# Altium schematic sheet ADC.SchDoc
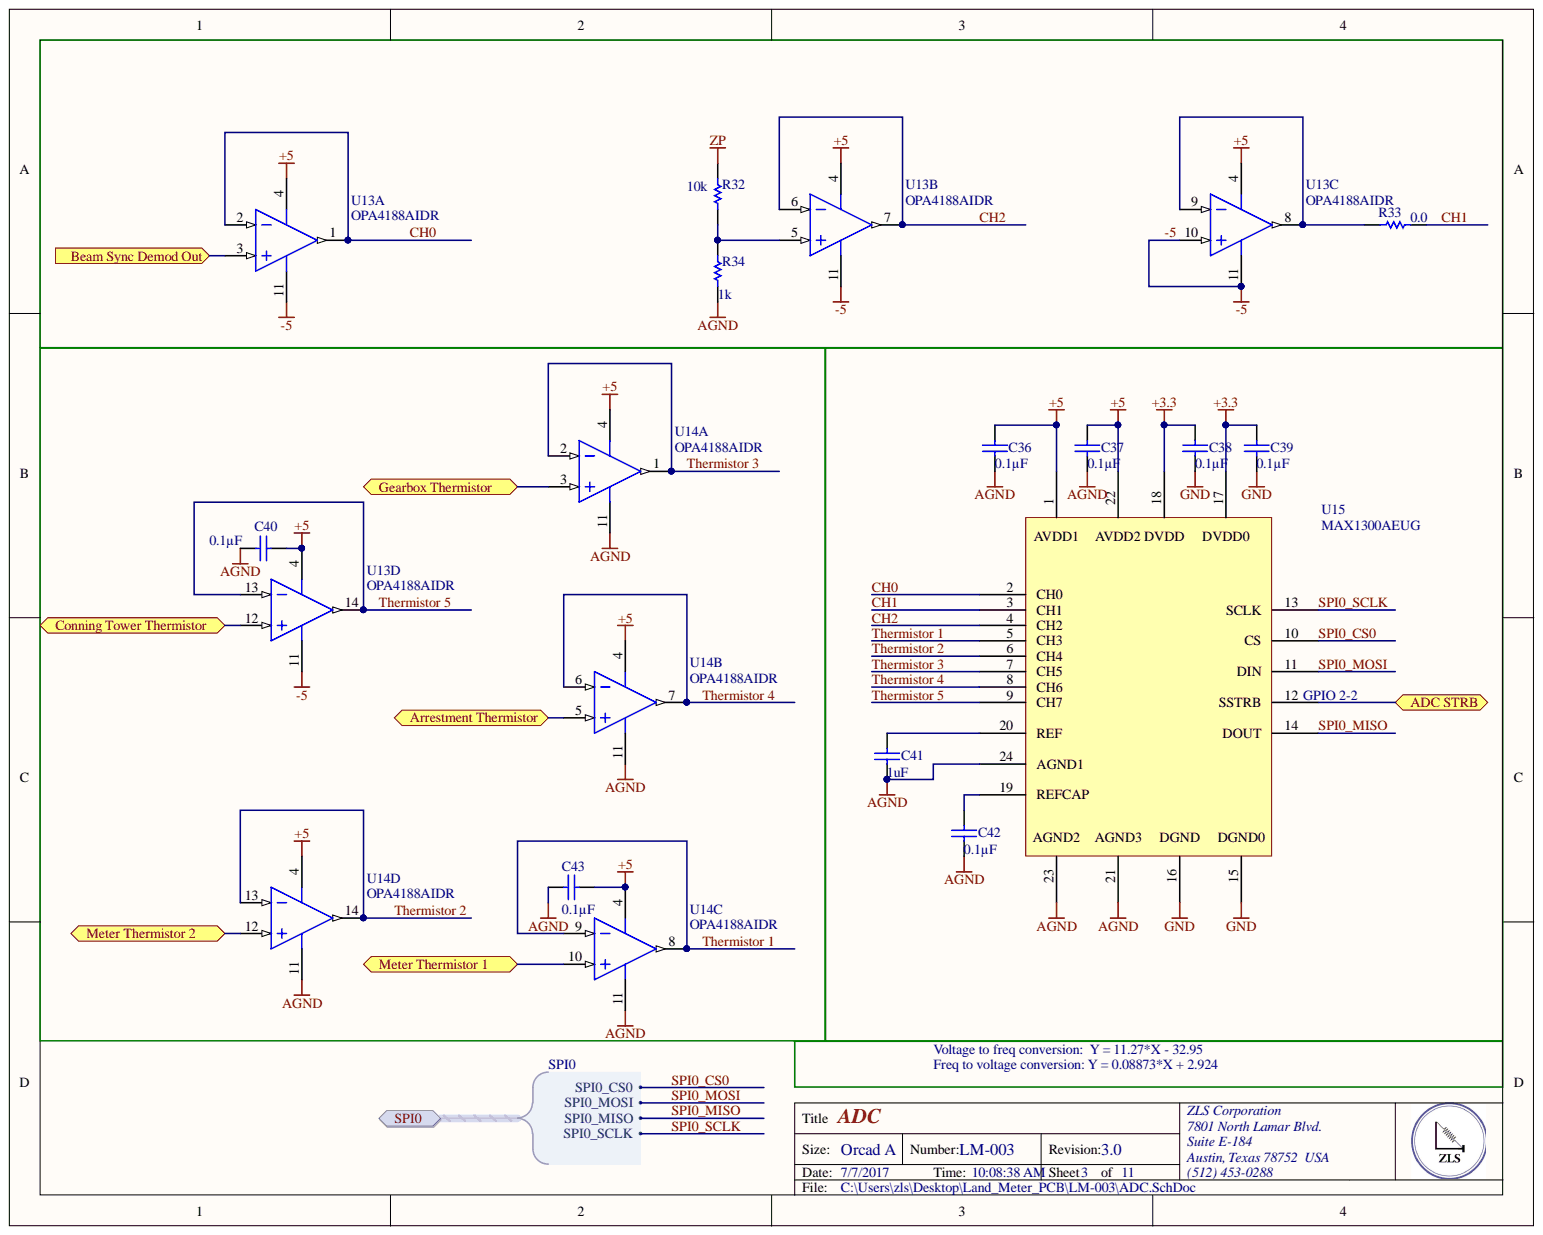
[diagram p0: ADC.SchDoc]

## Parts
C36  Capacitance: 0.1µF  [CAPC0805(2012)145_N]  (hidden comment: CAP CER 0.1UF 25V X7R 0805)
C37  Capacitance: 0.1µF  [CAPC0805(2012)145_N]  (hidden comment: CAP CER 0.1UF 25V X7R 0805)
C38  Capacitance: 0.1µF  [CAPC0805(2012)145_N]  (hidden comment: CAP CER 0.1UF 25V X7R 0805)
C39  Capacitance: 0.1µF  [CAPC0805(2012)145_N]  (hidden comment: CAP CER 0.1UF 25V X7R 0805)
C40  Capacitance: 0.1µF  [CAPC0805(2012)145_N]  (hidden comment: CAP CER 0.1UF 16V X7R 0805)
C41  Capacitance: 1uF  [CAPC0805(2012)145_N]  (hidden comment: CAP CER 1UF 16V X7R 0805)
C42  Capacitance: 0.1µF  [CAPC0805(2012)145_N]  (hidden comment: CAP CER 0.1UF 16V X7R 0805)
C43  Capacitance: 0.1µF  [CAPC0805(2012)145_N]  (hidden comment: CAP CER 0.1UF 16V X7R 0805)
R32  Resistance (Ohms): 10k  [RESC0805(2012)_M]  (hidden comment: RES SMD 10K OHM 1% 1/8W 0805)
R33  Resistance (Ohms): 0.0  [RESC0805(2012)_M]  (hidden comment: RES SMD 0.0 OHM JUMPER 1/8W 0805)
R34  Resistance (Ohms): 1k  [RESC0805(2012)_M]  (hidden comment: RES SMD 1K OHM 1% 1/8W 0805)
U13  OPA4188AIDR  [D0014A_L]  (parts A,B,C,D)
U14  OPA4188AIDR  [D0014A_L]  (parts A,B,C,D)
U15  MAX1300AEUG  [TSOP65P640X90-24N]

## Ports
ADC STRB, Arrestment Thermistor, Beam Sync Demod Out, Conning Tower Thermistor, Gearbox Thermistor, Meter Thermistor 1, Meter Thermistor 2, SPI0, SPI0.SPI0_CS0, SPI0.SPI0_MISO, SPI0.SPI0_MOSI, SPI0.SPI0_SCLK

## Nets
+3.3: C38.1 C39.1 U15.17 U15.18
+5: C36.1 C37.1 C40.2 C43.2 U13.4 U14.4 U15.1 U15.22
AGND: C36.2 C37.2 C40.1 C41.2 C42.2 C43.1 R34.1 U14.11 U15.21 U15.23 U15.24(AGND1)
GND: C38.2 C39.2 U15.15 U15.16
ZP: R32.2
-5: U13.10(-5) U13.11(-5)
ADC STRB: U15.12(SSTRB) ; port ADC STRB
Arrestment Thermistor: U14.5 ; port Arrestment Thermistor
Beam Sync Demod Out: U13.3 ; port Beam Sync Demod Out
CH0: U13.1 U13.2 U15.2(CH0)
CH1: R33.1 U15.3(CH1)
CH2: U13.6 U13.7 U15.4(CH2)
Conning Tower Thermistor: U13.12 ; port Conning Tower Thermistor
Gearbox Thermistor: U14.3 ; port Gearbox Thermistor
Meter Thermistor 1: U14.10 ; port Meter Thermistor 1
Meter Thermistor 2: U14.12 ; port Meter Thermistor 2
SPI0_CS0: U15.10(CS) ; port SPI0
SPI0_MISO: U15.14(DOUT) ; port SPI0
SPI0_MOSI: U15.11(DIN) ; port SPI0
SPI0_SCLK: U15.13(SCLK) ; port SPI0
Thermistor 1: U14.8 U14.9 U15.5(CH3)
Thermistor 2: U14.13 U14.14 U15.6(CH4)
Thermistor 3: U14.1 U14.2 U15.7(CH5)
Thermistor 4: U14.6 U14.7 U15.8(CH6)
Thermistor 5: U13.13 U13.14 U15.9(CH7)
NetC41_1: C41.1 U15.20(REF)
NetC42_1: C42.1 U15.19(REFCAP)
NetR32_1: R32.1 R34.2 U13.5
NetR33_2: R33.2 U13.8 U13.9

## Library data of the parts
C36-C39 = CMP-1036-04795-1: Manufacturer: Murata Electronics North America; Manufacturer Part Number: GRM21BR71E104KA01L; Tolerance: ±10%; Applications: General Purpose; Category: Capacitors; Component Kind: Standard; ComponentLink1Description: http://www.murata.com/~/media/webrenewal/support/library/catalog/products/capacitor/mlcc/c02e.ashx; ComponentLink1URL: http://www.murata.com/~/media/webrenewal/support/library/catalog/products/capacitor/mlcc/c02e.ashx; Description: CAP CER 0.1UF 25V X7R 0805; Features: -; Height - Seated (Max): -; Lead Spacing: -; Lead Style: -; Library Name: Altium Content Vault; Mounting Type: Surface Mount, MLCC; Operating Temperature: -55°C ~ 125°C; Package / Case: 0805 (2012 Metric); Packaging: Digi-Reel®; Part Status: Active; Pricing 1: 1=0.2, 10=0.145, 100=0.0683, 500=0.04788, 1000=0.03964 (USD); Ratings: -; RoHS: RoHS Compliant; Size / Dimension: 0.079" L x 0.049" W (2.00mm x 1.25mm); Stock 1: 891652; Supplier 1: Digi-Key; Supplier Part Number 1: 490-1673-6-ND; Temperature Coefficient: X7R; Thickness (Max): 0.053" (1.35mm); Voltage - Rated: 25V
C40 C42 C43 = CMP-1036-04795-1: Manufacturer: Murata Electronics North America; Manufacturer Part Number: GRM219R71C104KA01D; Tolerance: ±10%; Voltage - Rated: 25V; Applications: General Purpose; Category: Capacitors; Component Kind: Standard; ComponentLink1Description: http://www.murata.com/~/media/webrenewal/support/library/catalog/products/capacitor/mlcc/c02e.ashx; ComponentLink1URL: http://www.murata.com/~/media/webrenewal/support/library/catalog/products/capacitor/mlcc/c02e.ashx; Description: CAP CER 0.1UF 16V X7R 0805; Features: -; Height - Seated (Max): -; Lead Spacing: -; Lead Style: -; Library Name: Altium Content Vault; Mounting Type: Surface Mount, MLCC; Operating Temperature: -55°C ~ 125°C; Package / Case: 0805 (2012 Metric); Packaging: Digi-Reel®; Part Status: Active; Pricing 2: 1=0.14, 10=0.098, 100=0.0462, 500=0.0324, 1000=0.02683 (USD); Ratings: -; RoHS: RoHS Compliant; Size / Dimension: 0.079" L x 0.049" W (2.00mm x 1.25mm); Stock 2: 245331; Supplier 2: Digi-Key; Supplier Part Number 1: 490-1673-6-ND; Supplier Part Number 2: 490-1683-6-ND; Temperature Coefficient: X7R; Thickness (Max): 0.053" (1.35mm)
C41 = CMP-1036-04795-1: Manufacturer: Kemet; Manufacturer Part Number: C0805X105K4RACTU; Dielectric Material: Ceramic; Dissipation Factor: 3.5%; Tolerance: 10%; Case/Package: 0805; Case Code (Imperial): 0805; Case Code (Metric): 2012; Component Kind: Standard; ComponentLink1Description: https://datasheet.ciiva.com/7842/1698763-7842475.pdf; ComponentLink1URL: https://datasheet.ciiva.com/7842/1698763-7842475.pdf; ComponentLink2Description: https://datasheet.ciiva.com/7842/1698763-7842476.pdf; ComponentLink2URL: https://datasheet.ciiva.com/7842/1698763-7842476.pdf; ComponentLink3Description: https://datasheet.ciiva.com/14593/70096394-14593295.pdf; ComponentLink3URL: https://datasheet.ciiva.com/14593/70096394-14593295.pdf; Depth: 1.25mm; Description: CAP CER 1UF 16V X7R 0805; Dielectric: X7R; Height: 0.9mm; Length: 2.1mm; Library Name: Altium Content Vault; Max Operating Temperature: 125°C; Min Operating Temperature: -55°C; Mount: Surface Mount; Package Quantity: 1; Packaging: Tape and Reel; Pricing 1: 1=0.72, 10=0.528, 100=0.3623, 500=0.2868, 1000=0.2415 (USD); Radiation Hardening: No; REACH SVHC: No SVHC; Stock 1: 12821; Supplier 1: Digi-Key; Supplier Part Number 1: 399-5329-1-ND; Termination: SMD/SMT; Thickness: 0.039inch; Voltage: 16V; Voltage Rating: 16V; Voltage Rating (DC): 16V; Width: 1.25mm
R32 = CMP-1013-00017-1: Manufacturer: Vishay Dale; Manufacturer Part Number: CRCW080510K0FKTA; Power (Watts): 0.125W, 1/8W; Temperature Coefficient: ±100ppm/°C; Category: Resistors; Component Kind: Standard; ComponentLink1Description: http://www.vishay.com/docs/20008/dcrcw.pdf; ComponentLink1URL: http://www.vishay.com/docs/20008/dcrcw.pdf; Composition: Thick Film; Description: RES SMD 10K OHM 1% 1/8W 0805; Failure Rate: -; Features: Automotive AEC-Q200; Height - Seated (Max): 0.020" (0.50mm); Library Name: Altium Content Vault; Operating Temperature: -55°C ~ 155°C; Package / Case: 0805 (2012 Metric); Packaging: Digi-Reel®; Part Status: Active; Pricing 1: 1=0.14, 10=0.129, 100=0.0496, 1000=0.02183, 2500=0.01885 (USD); RoHS: RoHS non-compliant; Size / Dimension: 0.079" L x 0.049" W (2.00mm x 1.25mm); Stock 1: 5348; Supplier 1: Digi-Key; Supplier Device Package: 0805; Supplier Part Number 1: 541-3016-6-ND; Tolerance: ±1%
R33 = CMP-1013-00017-1: Manufacturer: Vishay Dale; Manufacturer Part Number: CRCW08050000Z0EA; Power (Watts): 0.125W, 1/8W; Category: Resistors; Component Kind: Standard; ComponentLink1Description: http://www.vishay.com/docs/20035/dcrcwe3.pdf; ComponentLink1URL: http://www.vishay.com/docs/20035/dcrcwe3.pdf; Composition: Thick Film; Description: RES SMD 0.0 OHM JUMPER 1/8W 0805; Failure Rate: -; Features: Automotive AEC-Q200; Height - Seated (Max): 0.020" (0.50mm); Library Name: Altium Content Vault; Operating Temperature: -55°C ~ 155°C; Package / Case: 0805 (2012 Metric); Packaging: Digi-Reel®; Part Status: Active; Pricing 1: 1=0.1, 10=0.026, 25=0.0184, 50=0.014, 100=0.0104, 250=0.00792, 500=0.00634, 1000=0.00466 (USD); RoHS: RoHS Compliant; Size / Dimension: 0.079" L x 0.049" W (2.00mm x 1.25mm); Stock 1: 869775; Supplier 1: Digi-Key; Supplier Device Package: 0805; Supplier Part Number 1: 541-0.0ADKR-ND; Temperature Coefficient: -; Tolerance: Jumper
R34 = CMP-1013-00017-1: Manufacturer: Vishay Dale; Manufacturer Part Number: CRCW08051K00FKTA; Power (Watts): 0.125W, 1/8W; Temperature Coefficient: ±100ppm/°C; Category: Resistors; Component Kind: Standard; ComponentLink1Description: http://www.vishay.com/docs/20008/dcrcw.pdf; ComponentLink1URL: http://www.vishay.com/docs/20008/dcrcw.pdf; Composition: Thick Film; Description: RES SMD 1K OHM 1% 1/8W 0805; Failure Rate: -; Features: Automotive AEC-Q200; Height - Seated (Max): 0.020" (0.50mm); Library Name: Altium Content Vault; Operating Temperature: -55°C ~ 155°C; Package / Case: 0805 (2012 Metric); Packaging: Digi-Reel®; Part Status: Active; Pricing 1: 1=0.14, 10=0.129, 100=0.0496, 1000=0.02183, 2500=0.01885 (USD); RoHS: RoHS non-compliant; Size / Dimension: 0.079" L x 0.049" W (2.00mm x 1.25mm); Stock 1: 57884; Supplier 1: Digi-Key; Supplier Device Package: 0805; Supplier Part Number 1: 541-1.00KAWDKR-ND; Tolerance: ±1%
U13 U14 = CMP-0809-00001-2: 0.03 uV/C, Low Noise, Rail-to-Rail Output, Zero-Drift Operational Amplifier, 4 to 36 V, -40 to 125 degC, 14-pin SOIC (D14), Green (RoHS & no Sb/Br); Manufacturer: Texas Instruments; CMRR(Min)(dB): 120; GBW(Typ)(MHz): 2; Iq per channel(Max)(mA): 0.475; Number of Channels: 4; Offset Drift(Typ)(uV/C): 0.03; Packing: LARGE T&R; Rating: Catalog; Slew Rate(Typ)(V/us): 0.8; SubFamily: High Supply Voltage Amplifier (>=30V), Low Noise Amp (<=10nV/rtHz), Low Offset Voltage Amplifier (<=500uV), Low Power Amp (<=500uA), Zero Drift Precision Amplifier; Total Supply Voltage(Max)(+5V is 5, +/-5V is 10): 36; Code_JEDEC: MS-012; Component Kind: Standard; ComponentLink1Description: Manufacturer URL; ComponentLink1URL: http://www.ti.com/; ComponentLink2Description: Datasheet; ComponentLink2URL: http://www.ti.com/lit/ds/symlink/opa4188.pdf; ComponentLink3Description: Package Specification; ComponentLink3URL: http://www.ti.com/lit/ml/mpds177g/mpds177g.pdf; DatasheetVersion: SBOS641B; Eco-Plan: Green (RoHS & no Sb/Br); Library Name: Altium Content Vault; Mounting Technology: Surface Mount; PackageDescription: 14-Pin Plastic Small Outline, Body 8.65 x 3.9 mm, Pitch 1.27 mm; PackageReference: D0014A; PackageVersion: 4040047-5/M, 06/2011; PartId: 1; PartNumber: OPA4188AIDR; Total Supply Voltage(Min)(+5V is 5, +/-5V is 10): 4
U15 = MAX1300AEUG: IC ADC 16BIT SRL 115KSPS 24TSSOP; Manufacturer 1: Maxim Integrated; Manufacturer Part Number 1: MAX1300AEUG+; Architecture: SAR; Category 1: Integrated Circuits (ICs); Configuration: MUX-PGA-S/H-ADC; Data Interface: SPI; Input Type: Differential, Single Ended; Number of A/D Converters: 1; Number of Bits: 16; Number of Inputs: 4, 8; Ratio - S/H: 1:1; Reference Type: External, Internal; Sampling Rate (Per Second): 115k; Voltage - Supply, Analog: 5V; Component Kind: Standard; ComponentLink1Description: http://datasheets.maximintegrated.com/en/ds/MAX1300-MAX1301.pdf; ComponentLink1URL: http://datasheets.maximintegrated.com/en/ds/MAX1300-MAX1301.pdf; Description 1: IC ADC 16BIT SRL 115KSPS 24TSSOP; Features: PGA; Operating Temperature: -40°C ~ 85°C; Package / Case: 24-TSSOP (0.173", 4.40mm Width); Packaging: Tube; Part Status: Active; Pricing 1: 1=13.94, 10=13.24, 50=13.16 (USD); RoHS 1: RoHS Compliant; Stock 1: 1553; Supplier 1: Digi-Key; Supplier 2: Mouser; Supplier Device Package: 24-TSSOP; Supplier Part Number 1: MAX1300AEUG+-ND; Supplier Part Number 2: 700-MAX1300AEUG+; Voltage - Supply, Digital: 5V; Library Name: ZLS_LIB.SchLib
